# Altium schematic sheet Current_Source.SchDoc
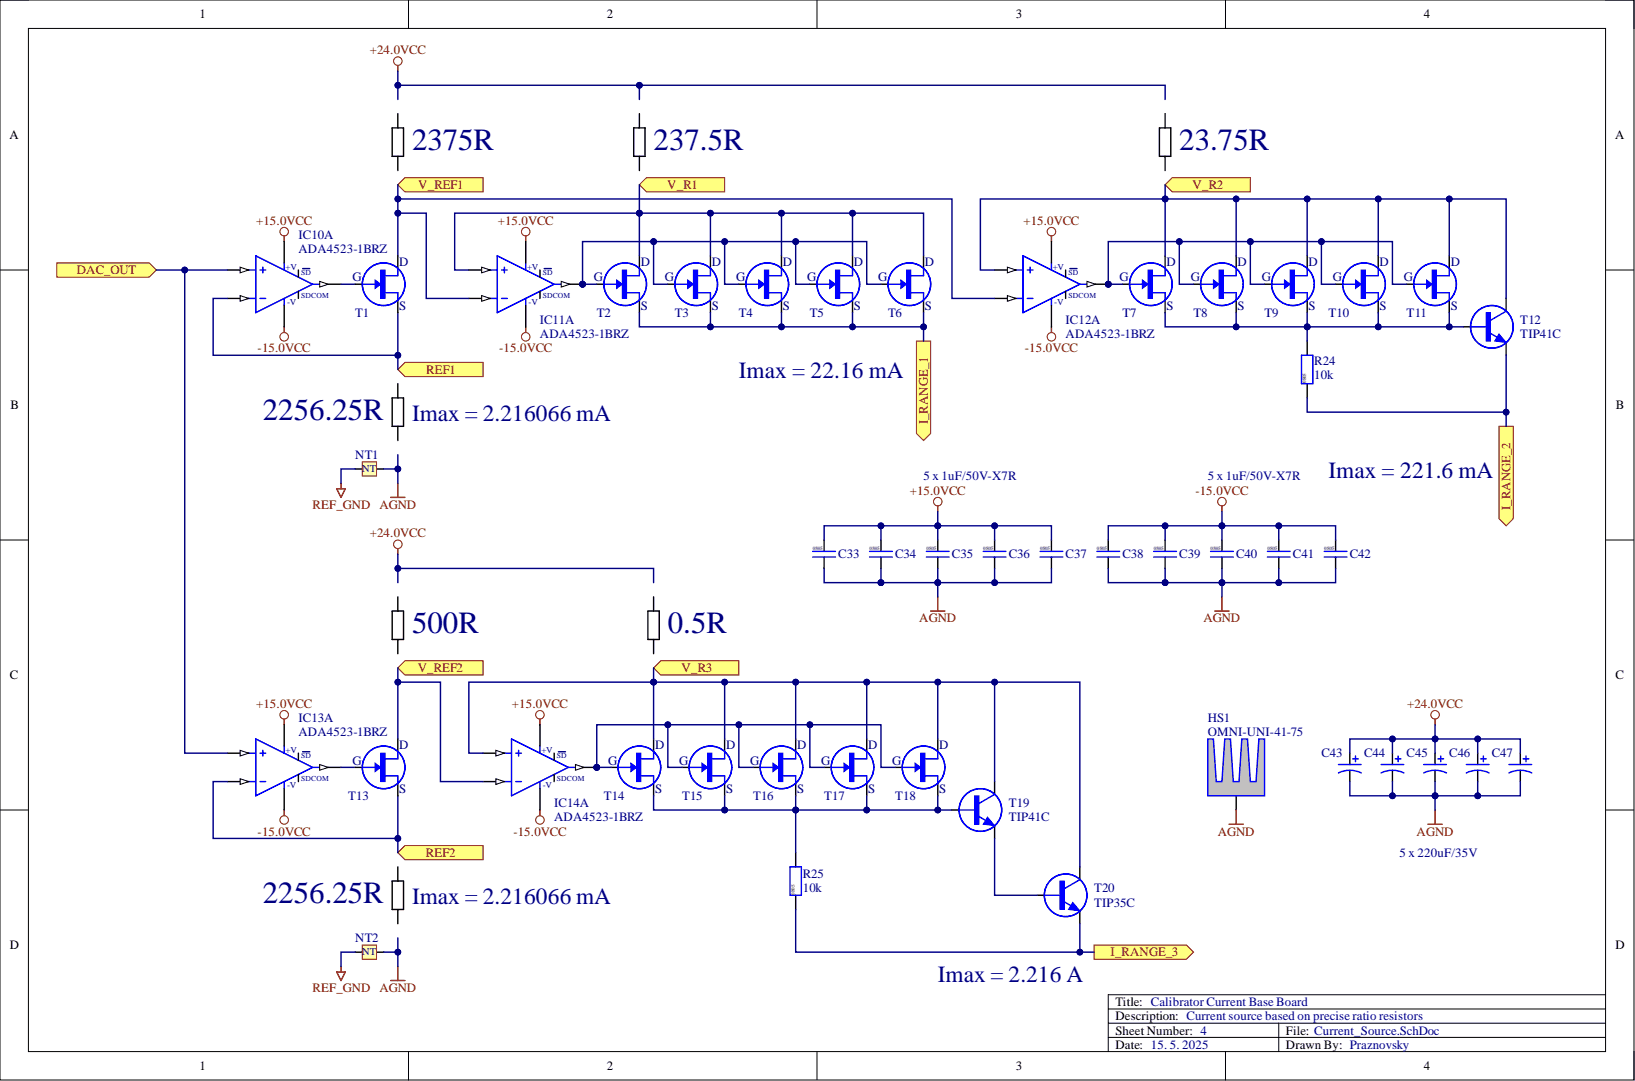
[diagram p0: Current_Source.SchDoc]

## Parts
C33  1uF/50V-X7R  [C0805]
C34  1uF/50V-X7R  [C0805]
C35  1uF/50V-X7R  [C0805]
C36  1uF/50V-X7R  [C0805]
C37  1uF/50V-X7R  [C0805]
C38  1uF/50V-X7R  [C0805]
C39  1uF/50V-X7R  [C0805]
C40  1uF/50V-X7R  [C0805]
C41  1uF/50V-X7R  [C0805]
C42  1uF/50V-X7R  [C0805]
C43  220uF/35V  [ELCO 8.0mm x 10.2mm]
C44  220uF/35V  [ELCO 8.0mm x 10.2mm]
C45  220uF/35V  [ELCO 8.0mm x 10.2mm]
C46  220uF/35V  [ELCO 8.0mm x 10.2mm]
C47  220uF/35V  [ELCO 8.0mm x 10.2mm]
HS1  OMNI-UNI-41-75  [OMNI-UNI-41-75]
IC10  ADA4523-1BRZ  [SO-8]  (parts A,B)
IC11  ADA4523-1BRZ  [SO-8]  (parts A,B)
IC12  ADA4523-1BRZ  [SO-8]  (parts A,B)
IC13  ADA4523-1BRZ  [SO-8]  (parts A,B)
IC14  ADA4523-1BRZ  [SO-8]  (parts A,B)
NT1  Net Tie  [Net Tie 2]  (value not drawn)
NT2  Net Tie  [Net Tie 2]  (value not drawn)
R24  10k  [R0805]
R25  10k  [R0805]
T1  J111  [TO-92]  (value not drawn)
T2  J111  [TO-92]  (value not drawn)
T3  J111  [TO-92]  (value not drawn)
T4  J111  [TO-92]  (value not drawn)
T5  J111  [TO-92]  (value not drawn)
T6  J111  [TO-92]  (value not drawn)
T7  J111  [TO-92]  (value not drawn)
T8  J111  [TO-92]  (value not drawn)
T9  J111  [TO-92]  (value not drawn)
T10  J111  [TO-92]  (value not drawn)
T11  J111  [TO-92]  (value not drawn)
T12  TIP41C  [TO-220-3]
T13  J111  [TO-92]  (value not drawn)
T14  J111  [TO-92]  (value not drawn)
T15  J111  [TO-92]  (value not drawn)
T16  J111  [TO-92]  (value not drawn)
T17  J111  [TO-92]  (value not drawn)
T18  J111  [TO-92]  (value not drawn)
T19  TIP41C  [TO-220-3]
T20  TIP35C  [TO-247-3]

## Ports
DAC_OUT, I_RANGE_1, I_RANGE_2, I_RANGE_3, REF1, REF2, V_R1, V_R2, V_R3, V_REF1, V_REF2

## Nets
+15.0VCC: C33.1 C34.1 C35.1 C36.1 C37.1 IC10.7 IC11.7 IC12.7 IC13.7 IC14.7
+24.0VCC: C43.1 C44.1 C45.1 C46.1 C47.1
-15.0VCC: C38.1 C39.1 C40.1 C41.1 C42.1 IC10.4 IC11.4 IC12.4 IC13.4 IC14.4
AGND: C33.2 C34.2 C35.2 C36.2 C37.2 C38.2 C39.2 C40.2 C41.2 C42.2 C43.2 C44.2 C45.2 C46.2 C47.2 HS1.MH1, MH2 NT1.2 NT2.2
REF_GND: NT1.1 NT2.1
DAC_OUT: IC10.3 IC13.3 ; port DAC_OUT
I_RANGE_1: T2.2 T3.2 T4.2 T5.2 T6.2 ; port I_RANGE_1
I_RANGE_2: R24.1 T12.3 ; port I_RANGE_2
I_RANGE_3: R25.1 T20.3 ; port I_RANGE_3
REF1: IC10.2 T1.2 ; port REF1
REF2: IC13.2 T13.2 ; port REF2
V_R1: IC11.3 T2.1 T3.1 T4.1 T5.1 T6.1 ; port V_R1
V_R2: IC12.3 T7.1 T8.1 T9.1 T10.1 T11.1 T12.2 ; port V_R2
V_R3: IC14.3 T14.1 T15.1 T16.1 T17.1 T18.1 T19.2 T20.2 ; port V_R3
V_REF1: IC11.2 IC12.2 T1.1 ; port V_REF1
V_REF2: IC14.2 T13.1 ; port V_REF2
NetIC10_1: IC10.1
NetIC10_6: IC10.6 T1.3
NetIC10_8: IC10.8
NetIC11_1: IC11.1
NetIC11_6: IC11.6 T2.3 T3.3 T4.3 T5.3 T6.3
NetIC11_8: IC11.8
NetIC12_1: IC12.1
NetIC12_6: IC12.6 T7.3 T8.3 T9.3 T10.3 T11.3
NetIC12_8: IC12.8
NetIC13_1: IC13.1
NetIC13_6: IC13.6 T13.3
NetIC13_8: IC13.8
NetIC14_1: IC14.1
NetIC14_6: IC14.6 T14.3 T15.3 T16.3 T17.3 T18.3
NetIC14_8: IC14.8
NetR24_2: R24.2 T7.2 T8.2 T9.2 T10.2 T11.2 T12.1
NetR25_2: R25.2 T14.2 T15.2 T16.2 T17.2 T18.2 T19.1
NetT19_3: T19.3 T20.1

## Library data of the parts
C33-C42 = CMP-00067-2: Capacitor 0805; Component Kind: Standard; Library Name: Calibrator
C43-C47 = CMP-00055-17: Electrolytic Capacitor; Component Kind: Standard; Library Name: Calibrator
HS1 = CMP-00093-7: Wakefield Thermal 41X75MM Universal omniKlip Heatsink; Component Kind: Standard; Library Name: Calibrator
IC10-IC14 = CMP-00078-6: 36 V, Low Noise, Zero Drift Op Amp; Component Kind: Standard; Library Name: Calibrator
NT1 NT2 = CMP-00047-10: Component Kind: Net Tie; Description: Net Tie; Library Name: Calibrator
R24 R25 = CMP-00063-4: Resistor 0805; Component Kind: Standard; Library Name: Calibrator
T1-T11 T13-T18 = CMP-00090-3: JFETs N-Channel Switch; Component Kind: Standard; Library Name: Calibrator
T12 T19 = CMP-00089-2: Complementary power transistors; Component Kind: Standard; Library Name: Calibrator
T20 = CMP-00091-1: Complementary power transistors; Component Kind: Standard; Library Name: Calibrator
